# Altium schematic sheet Drone.SchDoc
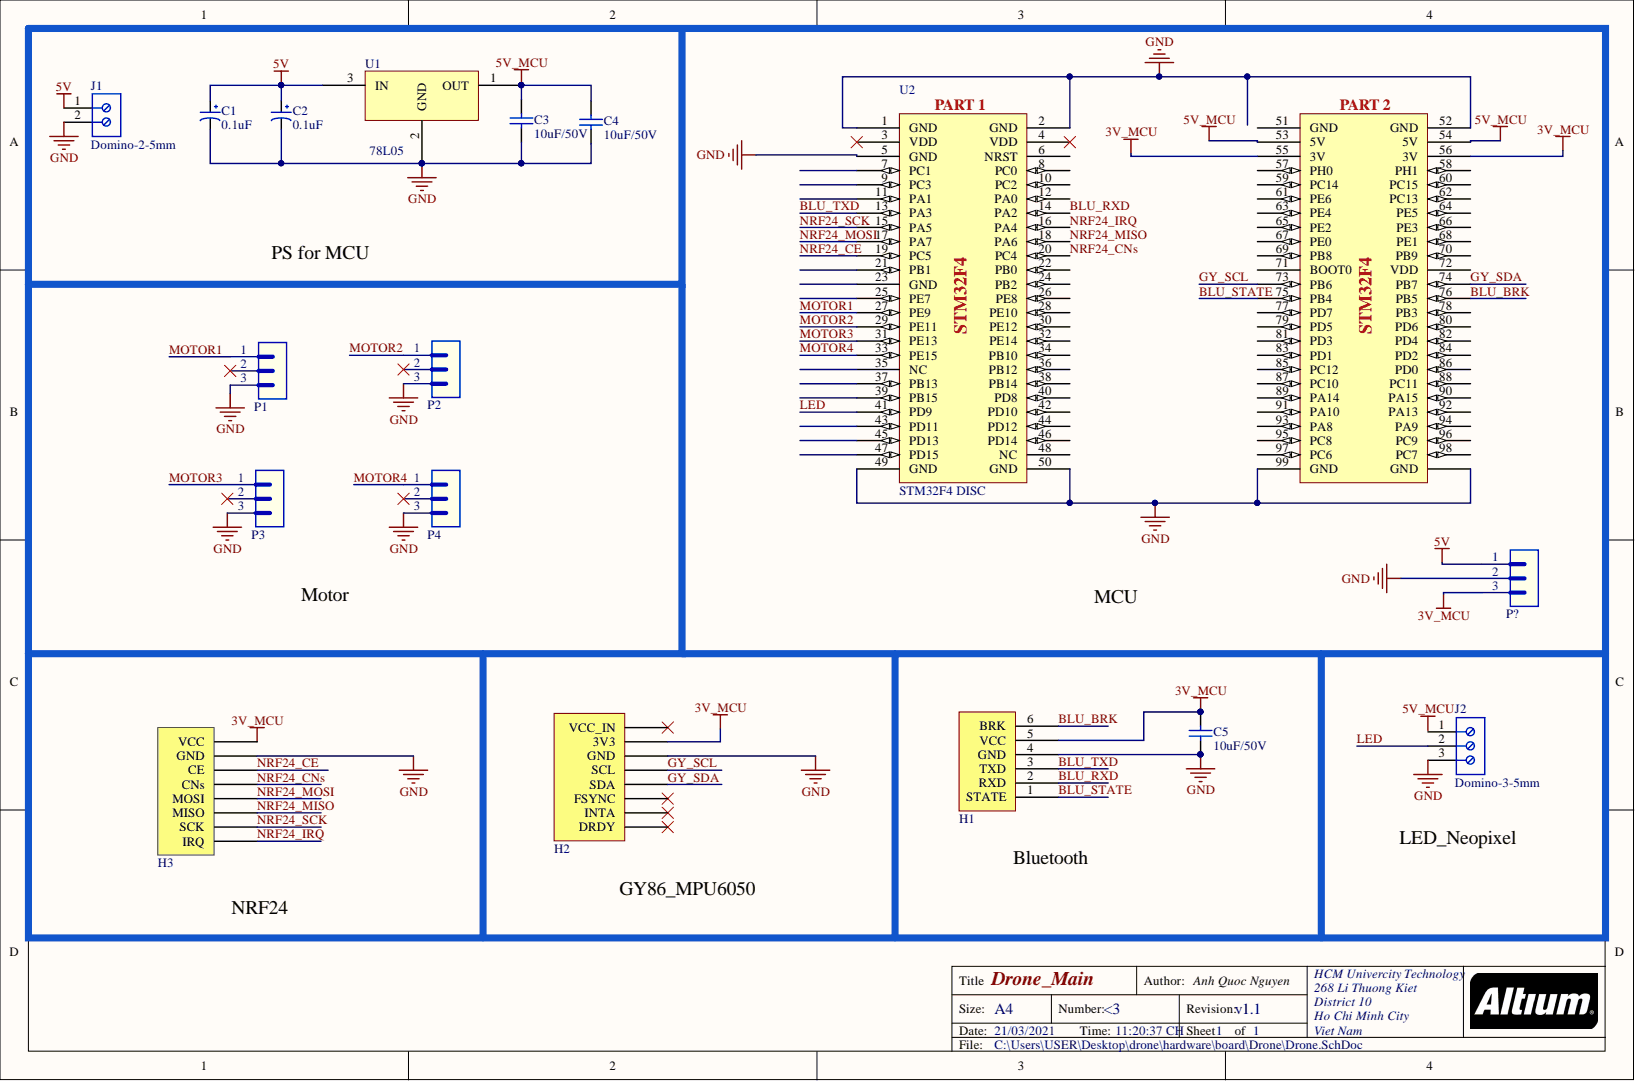
[diagram p0: Drone.SchDoc]

## Parts
C1  0.1uF  [tu hoa 5mm]
C2  0.1uF  [tu hoa 5mm]
C3  value 10uF/50V  [CAP-SM-0805]  (hidden comment: Cap-10uF-50V-0805)
C4  value 10uF/50V  [CAP-SM-0805]  (hidden comment: Cap-10uF-50V-0805)
C5  value 10uF/50V  [CAP-SM-0805]  (hidden comment: Cap-10uF-50V-0805)
H1  HC-05-06-TH  [HM-10S CC2541]  (value not drawn)
H2  MPU6050_Header  [XH B8B]  (value not drawn)
H3  NRF24  [XH B8B]
J1  Domino-2-5mm  [DOMINO-2-5MM]
J2  Domino-3-5mm  [DOMINO-3-5mm]
P1  Header 3  [JP3]  (value not drawn)
P2  Header 3  [JP3]  (value not drawn)
P3  Header 3  [JP3]  (value not drawn)
P4  Header 3  [JP3]  (value not drawn)
P?  Header 3  [JP3]  (value not drawn)
U1  78L05  [SOT89-150P410X160-3N]
U2  STM32F4 DISC  [STM32F4 DISC]

## Nets
3V_MCU: C5.1 H1.5(VCC) H2.2(3V3) H3.1(VCC) P?.3 U2.55 U2.56(3V)
5V: C1.1 C2.1 J1.1 P?.1 U1.3
5V_MCU: C3.1 C4.1 U1.1 U2.53 U2.54(5V)
GND: C1.2 C2.2 C3.2 C4.2 C5.2 H1.4(GND) H2.3(GND) H3.2(GND) J1.2 J2.3 P1.3 P2.3 P3.3 P4.3 P?.2 U1.2 U2.1(GND) U2.2 U2.5(GND) U2.49(GND) U2.50 U2.52(GND) U2.99 U2.GND
BLU_BRK: H1.6(BRK) U2.76(PB5)
BLU_RXD: H1.2(RXD) U2.14(BLU_RXD)
BLU_STATE: H1.1(STATE) U2.75
BLU_TXD: H1.3(TXD) U2.13(PA3)
GY_SCL: H2.4(SCL) U2.73(GY_SCL)
GY_SDA: H2.5(SDA) U2.74(PB7)
LED: J2.2 U2.41(PD9)
MOTOR1: P1.1 U2.27(PE9)
MOTOR2: P2.1 U2.29(PE11)
MOTOR3: P3.1 U2.31(PE13)
MOTOR4: P4.1 U2.33(PE15)
NRF24_CE: H3.3(CE) U2.19(PC5)
NRF24_CNs: H3.4(CNs) U2.20(NRF24_CNs)
NRF24_IRQ: H3.8(IRQ) U2.16(NRF24_IRQ)
NRF24_MISO: H3.6(MISO) U2.18
NRF24_MOSI: H3.5(MOSI) U2.17(PA7)
NRF24_SCK: H3.7(SCK) U2.15(PA5)

Not connected: H2 1(VCC_IN) 6(FSYNC) 7(INTA) 8(DRDY); J2 1; P1 2; P2 2; P3 2; P4 2; U2 3(VDD) 4 6 7(PC1) 8 9(PC3) 10 11(PA1) 12 21(PB1) 22 23(GND) 24 25(PE7) 26 28 30 32 34 35(NC) 36 37(PB13) 38 39(PB15) 40 42 43(PD11) 44 45(PD13) 46 47(PD15) 48 51 57 58(PH1) 59 60(PC15) 61 62(PC13) 63 64(PE5) 65 66(PE3) 67 68(PE1) 69 70(PB9) 71 72(VDD) 77 78(PB3) 79 80(PD6) 81 82(PD4) 83 84(PD2) 85 86(PD0) 87 88(PC11) 89 90(PA15) 91 92(PA13) 93 94(PA9) 95 96(PC9) 97 98(PC7)

## Library data of the parts
C1 C2 = Tu Hoa 0.1uF 50V 5x11: Cắm; Don_Vi: Con; Ma_Hang: MH006853; So_Chan: 2; Ten_Linh_Kien: Tụ Hóa 0.1uF 50V; Chung_Loai: Cắm; Component Kind: Standard; Library Name: THU VIEN SCH.SchLib
C3-C5 = Cap-10uF-50V-0805: CAP CER 10UF 50V X5R 0805; Manufacturer: Yageo; Manufacturer Part Number: CC0805KKX5R9BB106; Component Kind: Standard; Library Name: Capacitor.SchLib; Local Supplier: thegioiic; Local Supplier Link: https://thegioiic.com/products/tu-10uf-50v-0805; Supplier 1: Digikey; Supplier 1 Link: https://www.digikey.com/product-detail/en/yageo/CC0805KKX5R9BB106/311-3499-2-ND/7164383; Supplier 1 Part Number: 311-3499-2-ND
H1 = HM-10S CC2541: Component Kind: Standard; Local Supplier: HShop; Local Supplier Link: https://hshop.vn/products/mach-thu-phat-bluetooth-hc-06-do-ra-chon-slave; Local Supplier Part Number: HS000882; Library Name: Drone.SchLib
J1 = Domino-2-5mm: WR-TBL Serie 1347 Horizontal Cable Entry, Pressure Clamp, pitch 5mm, 2p; Part Number: 691134710002; Compliance: Lead free / RoHS; Modularity: Yes; PCB/Cable/Panel: PCB; Pin Number: 2; Rated Current [UL]: 16A; Working Voltage [UL]: 300VAC; Application: Pressure Clamp; Component Kind: Standard; ComponentLink1Description: Manufacturer; ComponentLink1URL: http://www.we-online.de; ComponentLink2Description: Datasheet; ComponentLink2URL: https://katalog.we-online.de/em/datasheet/691134710002.pdf; Library Name: Header-connector.SchLib; Mounting Technology: THT; Operating Temperature: -30 to +120degC; Packaging: Box; Pitch: 5mm; Published Date: October 2019; Type: Horizontal; Publisher: Wurth Elektronik
J2 = Domino-3-5mm: WR-TBL Serie 1347 Horizontal Cable Entry, Pressure Clamp, pitch 5mm, 3p; Part Number: 691134710003; Compliance: Lead free / RoHS; Modularity: Yes; PCB/Cable/Panel: PCB; Pin Number: 3; Rated Current [UL]: 16A; Working Voltage [UL]: 300VAC; Application: Pressure Clamp; Component Kind: Standard; ComponentLink1Description: Manufacturer; ComponentLink1URL: http://www.we-online.de; ComponentLink2Description: Datasheet; ComponentLink2URL: https://katalog.we-online.de/em/datasheet/691134710003.pdf; Library Name: Header-connector.SchLib; Mounting Technology: THT; Operating Temperature: -30 to +120degC; Packaging: Box; Pitch: 5mm; Published Date: October 2019; Type: Horizontal; Publisher: Wurth Elektronik
P1-P4 P? = Header 3: Header, 3-Pin; Component Kind: Standard; LatestRevisionDate: 17-Jul-2002; LatestRevisionNote: Re-released for DXP Platform.; Library Name: Header-connector.SchLib; Publisher: Altium Limited
U1 = 78L05: Dán; Don_Vi: Con; Ma_Hang: MH004845; Ten_Linh_Kien: 78L05 SOT89 0.1A 5V; Chung_Loai: Dán; Component Kind: Standard; Library Name: THU VIEN SCH.SchLib
U2 = STM32F4 DISC: STM32F4 Discovery board. Chip on Board is Stm32f411VET6. Speed is 150MHz; Component Kind: Standard; Library Name: Drone.SchLib
H2 = MPU6050_Header: Component Kind: Standard; Library Name: Drone.SchLib
H3 = NRF24: Component Kind: Standard; Library Name: Drone.SchLib
